# Altium schematic sheet SHEET_2.SchDoc
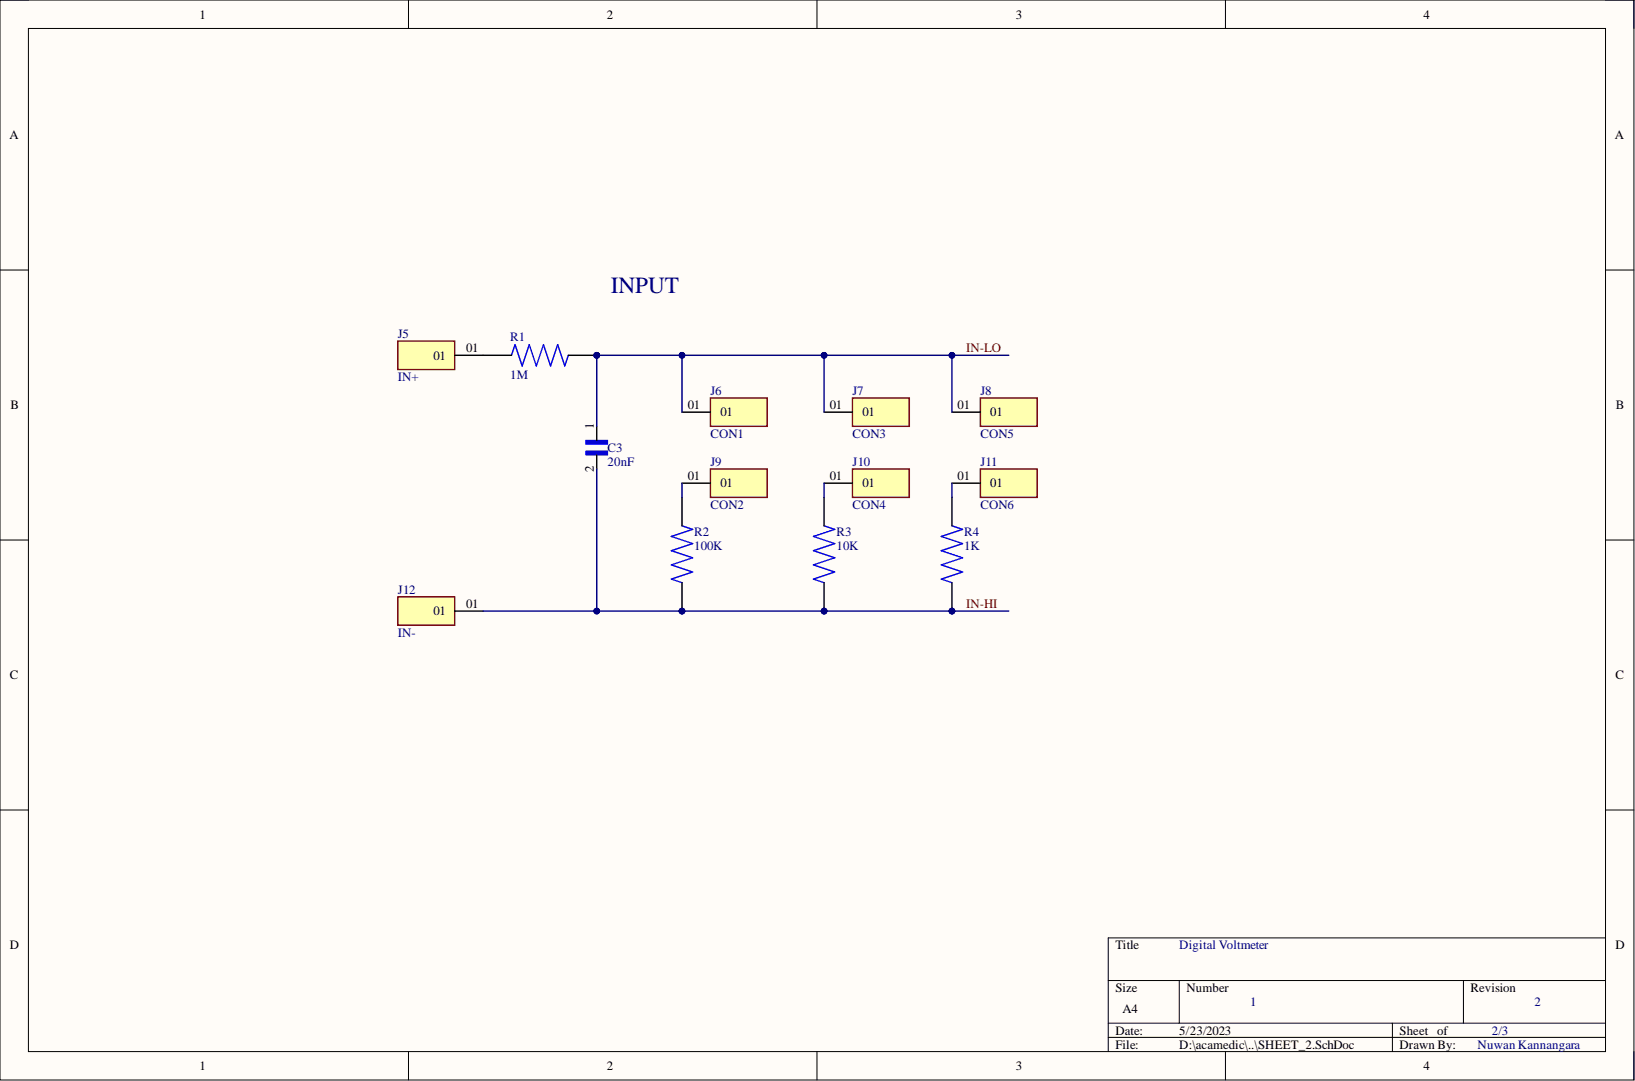
[diagram p0: SHEET_2.SchDoc]

## Parts
C3  20nF  [CAPRB500W50L550T350H600]
J5  IN+  [SAMTEC_MTMM-101-XX-X-S-XXX]
J6  CON1  [SAMTEC_MTMM-101-XX-X-S-XXX]
J7  CON3  [SAMTEC_MTMM-101-XX-X-S-XXX]
J8  CON5  [SAMTEC_MTMM-101-XX-X-S-XXX]
J9  CON2  [SAMTEC_MTMM-101-XX-X-S-XXX]
J10  CON4  [SAMTEC_MTMM-101-XX-X-S-XXX]
J11  CON6  [SAMTEC_MTMM-101-XX-X-S-XXX]
J12  IN-  [SAMTEC_MTMM-101-XX-X-S-XXX]
R1  1M  [RESAD1100W55L680D260]
R2  100K  [RESAD1100W55L680D260]
R3  10K  [RESAD1100W55L680D260]
R4  1K  [RESAD1100W55L680D260]

## Nets
IN-HI: C3.2 J12.01 R2.2 R3.2 R4.2
IN-LO: C3.1 J6.01 J7.01 J8.01 R1.2
NetJ5_01: J5.01 R1.1
NetJ9_01: J9.01 R2.1
NetJ10_01: J10.01 R3.1
NetJ11_01: J11.01 R4.1

## Library data of the parts
C3 = FG26X7R2E104KNT06: 0.1ÂµF Â±10% 250V Ceramic Capacitor X7R Radial; MF: TDK; Value: $0.51; Availability: In Stock; Check_prices: https://www.snapeda.com/parts/FG26X7R2E104KNT06/TDK/view-part/?ref=eda; Component Kind: Standard; Library Name: FG26X7R2E104KNT06.SchLib; MP: FG26X7R2E104KNT06; Package: Radial TDK; Price: None; Purchase-URL: https://pricing.snapeda.com/search/part/FG26X7R2E104KNT06/?ref=eda; SnapEDA_Link: https://www.snapeda.com/parts/FG26X7R2E104KNT06/TDK/view-part/?ref=snap; Supplier 1: Mouser
J5-J12 = MTMM-101-XX-X-S-XXX: Connector Header position; Availability: Unavailable; Component Kind: Standard; Library Name: MTMM-101-XX-X-S-XXX.SchLib; MP: MTMM-101-XX-X-S-XXX; Package: None; Price: None
R1-R4 = MFR-25FBF52-1K: 1.78 kOhms Â±1% 0.25W, 1/4W Through Hole Resistor Axial - Metal Film; MF: Yageo; Value: $0.05; Availability: In Stock; Check_prices: https://www.snapeda.com/parts/MFR-25FBF52-1K/Yageo/view-part/?ref=eda; Component Kind: Standard; Library Name: MFR-25FBF52-1K.SchLib; MP: MFR-25FBF52-1K; Package: Axial Yageo; Price: None; Purchase-URL: https://pricing.snapeda.com/search/part/MFR-25FBF52-1K/?ref=eda; SnapEDA_Link: https://www.snapeda.com/parts/MFR-25FBF52-1K/Yageo/view-part/?ref=snap; Supplier 1: Mouser
